# Altium schematic sheet msxslot.SchDoc
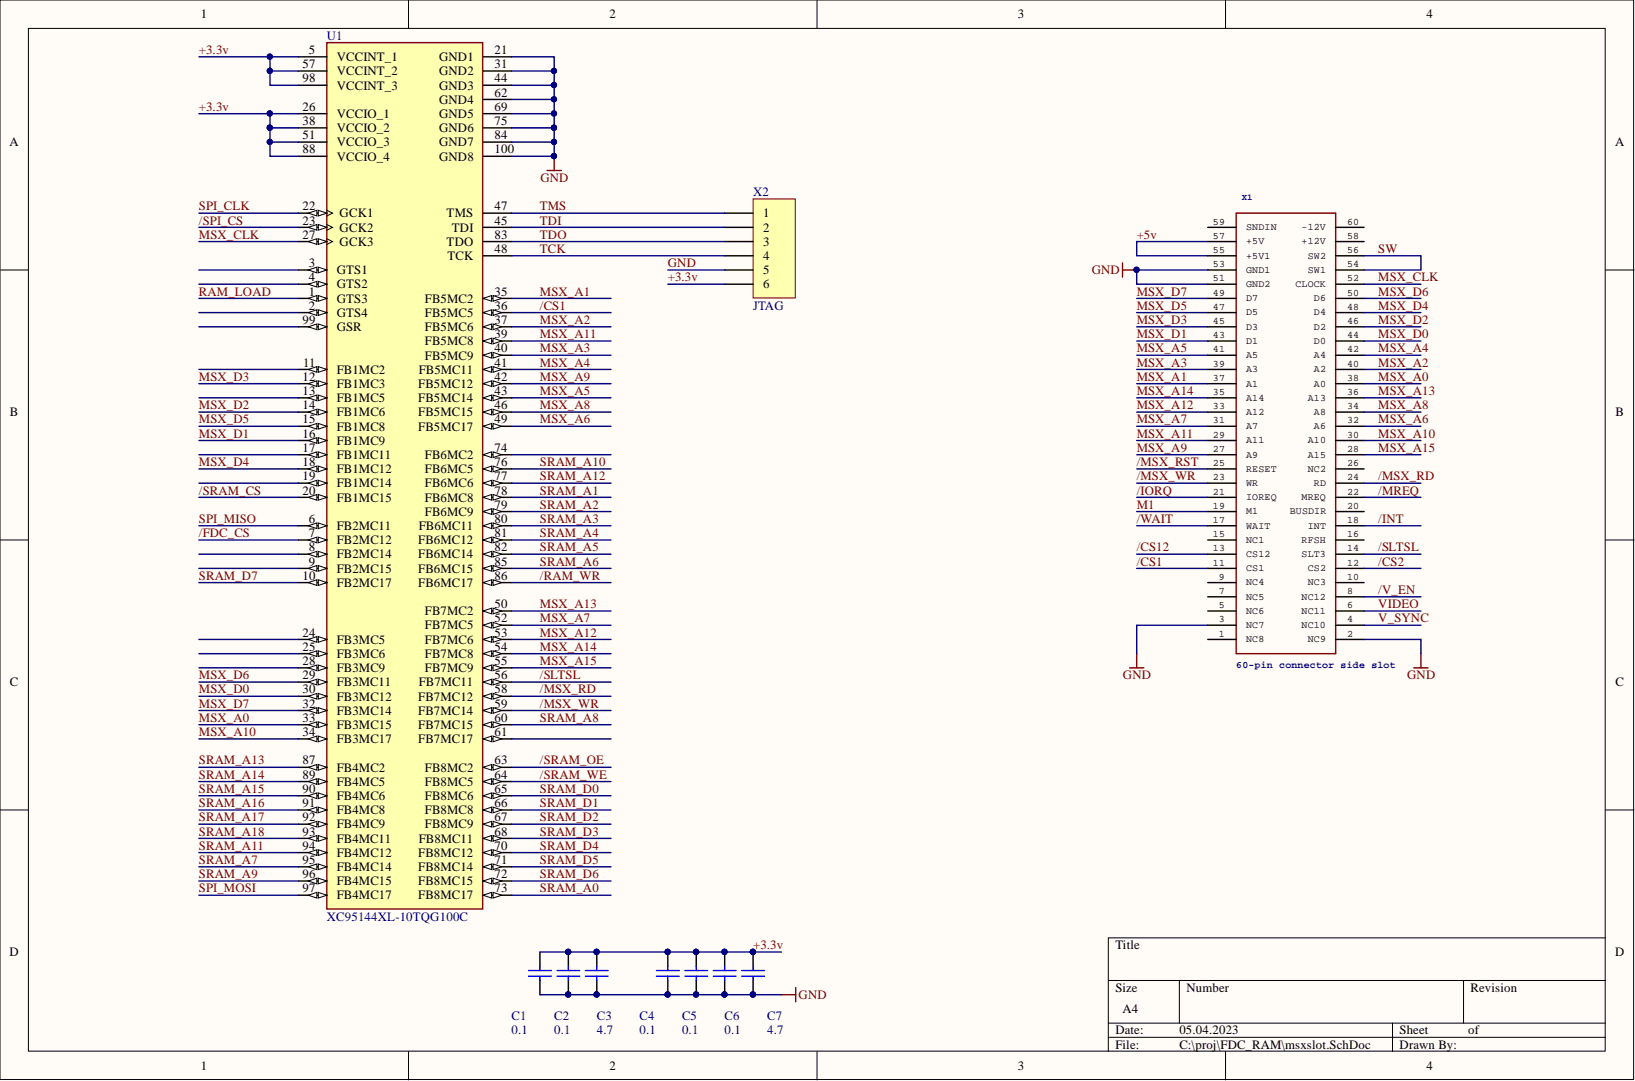
[diagram p0: msxslot.SchDoc]

## Parts
C1  value 0.1  [0603_cap]  (hidden comment: CAP_0.1uF_0603)
C2  value 0.1  [0603_cap]  (hidden comment: CAP_0.1uF_0603)
C3  value 4.7  [0603_cap]  (hidden comment: CAP_4.7uF_0603)
C4  value 0.1  [0603_cap]  (hidden comment: CAP_0.1uF_0603)
C5  value 0.1  [0603_cap]  (hidden comment: CAP_0.1uF_0603)
C6  value 0.1  [0603_cap]  (hidden comment: CAP_0.1uF_0603)
C7  value 4.7  [0603_cap]  (hidden comment: CAP_4.7uF_0603)
U1  XC95144XL-10TQG100C  [TQFP100]
X1  60-pin connector side slot  [SFG-60]
X2  JTAG  [HDR1X6]

## Nets
+3.3v: C1.2 C2.2 C3.2 C4.2 C5.2 C6.2 C7.2 U1.5(VCCINT_1) U1.26(VCCIO_1) U1.38(VCCIO_2) U1.51(VCCIO_3) U1.57(VCCINT_2) U1.88(VCCIO_4) U1.98(VCCINT_3) X2.6
+5v: X1.55(+5V1) X1.57(+5V)
/CS1: U1.36(FB5MC5) X1.11(CS1)
/CS2: X1.12(CS2)
/CS12: X1.13(CS12)
/FDC_CS: U1.7(FB2MC12)
/INT: X1.18(INT)
/IORQ: X1.21(IOREQ)
/MREQ: X1.22(MREQ)
/MSX_RD: U1.58(FB7MC12) X1.24(RD)
/MSX_RST: X1.25(RESET)
/MSX_WR: U1.59(FB7MC14) X1.23(WR)
/RAM_WR: U1.86(FB6MC17)
/SLTSL: U1.56(FB7MC11) X1.14(SLT3)
/SPI_CS: U1.23(GCK2)
/SRAM_CS: U1.20(FB1MC15)
/SRAM_OE: U1.63(FB8MC2)
/SRAM_WE: U1.64(FB8MC5)
/V_EN: X1.8(NC12)
/WAIT: X1.17(WAIT)
GND: C1.1 C2.1 C3.1 C4.1 C5.1 C6.1 C7.1 U1.21(GND1) U1.31(GND2) U1.44(GND3) U1.62(GND4) U1.69(GND5) U1.75(GND6) U1.84(GND7) U1.100(GND8) X1.2(NC9) X1.3(NC7) X1.51(GND2) X1.53(GND1) X2.5
M1: X1.19(M1)
MSX_A0: U1.33(FB3MC15) X1.38(A0)
MSX_A1: U1.35(FB5MC2) X1.37(A1)
MSX_A2: U1.37(FB5MC6) X1.40(A2)
MSX_A3: U1.40(FB5MC9) X1.39(A3)
MSX_A4: U1.41(FB5MC11) X1.42(A4)
MSX_A5: U1.43(FB5MC14) X1.41(A5)
MSX_A6: U1.49(FB5MC17) X1.32(A6)
MSX_A7: U1.52(FB7MC5) X1.31(A7)
MSX_A8: U1.46(FB5MC15) X1.34(A8)
MSX_A9: U1.42(FB5MC12) X1.27(A9)
MSX_A10: U1.34(FB3MC17) X1.30(A10)
MSX_A11: U1.39(FB5MC8) X1.29(A11)
MSX_A12: U1.53(FB7MC6) X1.33(A12)
MSX_A13: U1.50(FB7MC2) X1.36(A13)
MSX_A14: U1.54(FB7MC8) X1.35(A14)
MSX_A15: U1.55(FB7MC9) X1.28(A15)
MSX_CLK: U1.27(GCK3) X1.52(CLOCK)
MSX_D0: U1.30(FB3MC12) X1.44(D0)
MSX_D1: U1.16(FB1MC9) X1.43(D1)
MSX_D2: U1.14(FB1MC6) X1.46(D2)
MSX_D3: U1.12(FB1MC3) X1.45(D3)
MSX_D4: U1.18(FB1MC12) X1.48(D4)
MSX_D5: U1.15(FB1MC8) X1.47(D5)
MSX_D6: U1.29(FB3MC11) X1.50(D6)
MSX_D7: U1.32(FB3MC14) X1.49(D7)
RAM_LOAD: U1.1(GTS3)
SPI_CLK: U1.22(GCK1)
SPI_MISO: U1.6(FB2MC11)
SPI_MOSI: U1.97(FB4MC17)
SRAM_A0: U1.73(FB8MC17)
SRAM_A1: U1.78(FB6MC8)
SRAM_A2: U1.79(FB6MC9)
SRAM_A3: U1.80(FB6MC11)
SRAM_A4: U1.81(FB6MC12)
SRAM_A5: U1.82(FB6MC14)
SRAM_A6: U1.85(FB6MC15)
SRAM_A7: U1.95(FB4MC14)
SRAM_A8: U1.60(FB7MC15)
SRAM_A9: U1.96(FB4MC15)
SRAM_A10: U1.76(FB6MC5)
SRAM_A11: U1.94(FB4MC12)
SRAM_A12: U1.77(FB6MC6)
SRAM_A13: U1.87(FB4MC2)
SRAM_A14: U1.89(FB4MC5)
SRAM_A15: U1.90(FB4MC6)
SRAM_A16: U1.91(FB4MC8)
SRAM_A17: U1.92(FB4MC9)
SRAM_A18: U1.93(FB4MC11)
SRAM_D0: U1.65(FB8MC6)
SRAM_D1: U1.66(FB8MC8)
SRAM_D2: U1.67(FB8MC9)
SRAM_D3: U1.68(FB8MC11)
SRAM_D4: U1.70(FB8MC12)
SRAM_D5: U1.71(FB8MC14)
SRAM_D6: U1.72(FB8MC15)
SRAM_D7: U1.10(FB2MC17)
SW: X1.54(SW1) X1.56(SW2)
TCK: U1.48(TCK) X2.4
TDI: U1.45(TDI) X2.2
TDO: U1.83(TDO) X2.3
TMS: U1.47(TMS) X2.1
V_SYNC: X1.4(NC10)
VIDEO: X1.6(NC11)

Not connected: U1 2(GTS4) 3(GTS1) 4(GTS2) 8(FB2MC14) 9(FB2MC15) 11(FB1MC2) 13(FB1MC5) 17(FB1MC11) 19(FB1MC14) 24(FB3MC5) 25(FB3MC6) 28(FB3MC9) 61(FB7MC17) 74(FB6MC2) 99(GSR); X1 1(NC8) 5(NC6) 7(NC5) 9(NC4) 10(NC3) 15(NC1) 16(RFSH) 20(BUSDIR) 26(NC2) 58(+12V) 59(SNDIN) 60(-12V)

## Library data of the parts
C1 C2 C4-C6 = CAP_0.1uF_0603: CAP 0.1uF 63V CERAMIC X7R 0603; Manufacturer: Kemet; PartNumber: C0603C104K3RACTU; Component Kind: Standard; Datasheet link: http://www.kemet.com/kemet/web/homepage/kechome.nsf/vapubfilesname/F3102TA.pdf/$file/F3102TA.pdf; DigiKeyPartNumber: 399-1281-2-ND; Manufacturer URL: http://www.kemet.com/; RoHS: 1; Library Name: sunrise.SchLib
C3 C7 = CAP_4.7uF_0603: CAP 4.7uF 63V CERAMIC X7R 0603; Manufacturer: Kemet; PartNumber: C0603C104K3RACTU; Component Kind: Standard; Datasheet link: http://www.kemet.com/kemet/web/homepage/kechome.nsf/vapubfilesname/F3102TA.pdf/$file/F3102TA.pdf; DigiKeyPartNumber: 399-1281-2-ND; Manufacturer URL: http://www.kemet.com/; RoHS: 1; Library Name: sunrise.SchLib
U1 = XC95144XL-10TQG100C: IC CPLD 144MC 10NS 100TQFP; MF: Xilinx Inc.; Availability: Warning; Check_prices: https://www.snapeda.com/parts/XC95144XL-10TQG100C/Xilinx%20Inc./view-part/42161/?ref=eda; Component Kind: Standard; MP: XC95144XL-10TQG100C; Package: TQFP-100 Xilinx; Price: None; Purchase-URL: https://pricing.snapeda.com/search/part/XC95144XL-10TQG100C/?ref=eda; SnapEDA_Link: https://www.snapeda.com/parts/XC95144XL-10TQG100C/Xilinx%20Inc./view-part/42161/?ref=snap; Library Name: fdc_ram.SchLib
X1 = SFG-60: GateName_1: G$1; Component Kind: Standard; DeviceSetName: SFG-60; LibraryName: sfg-60; Package: SFG-60; SymbolName_1: SFG-60; Library Name: fdc_ram.SchLib
X2 = Header 6: Header, 6-Pin; Component Kind: Standard; LatestRevisionDate: 17-Jul-2002; LatestRevisionNote: Re-released for DXP Platform.; Library Name: Miscellaneous Connectors.IntLib; Signal Integrity: Connector; Publisher: Altium Limited
